# Altium schematic sheet 最小系统.SchDoc
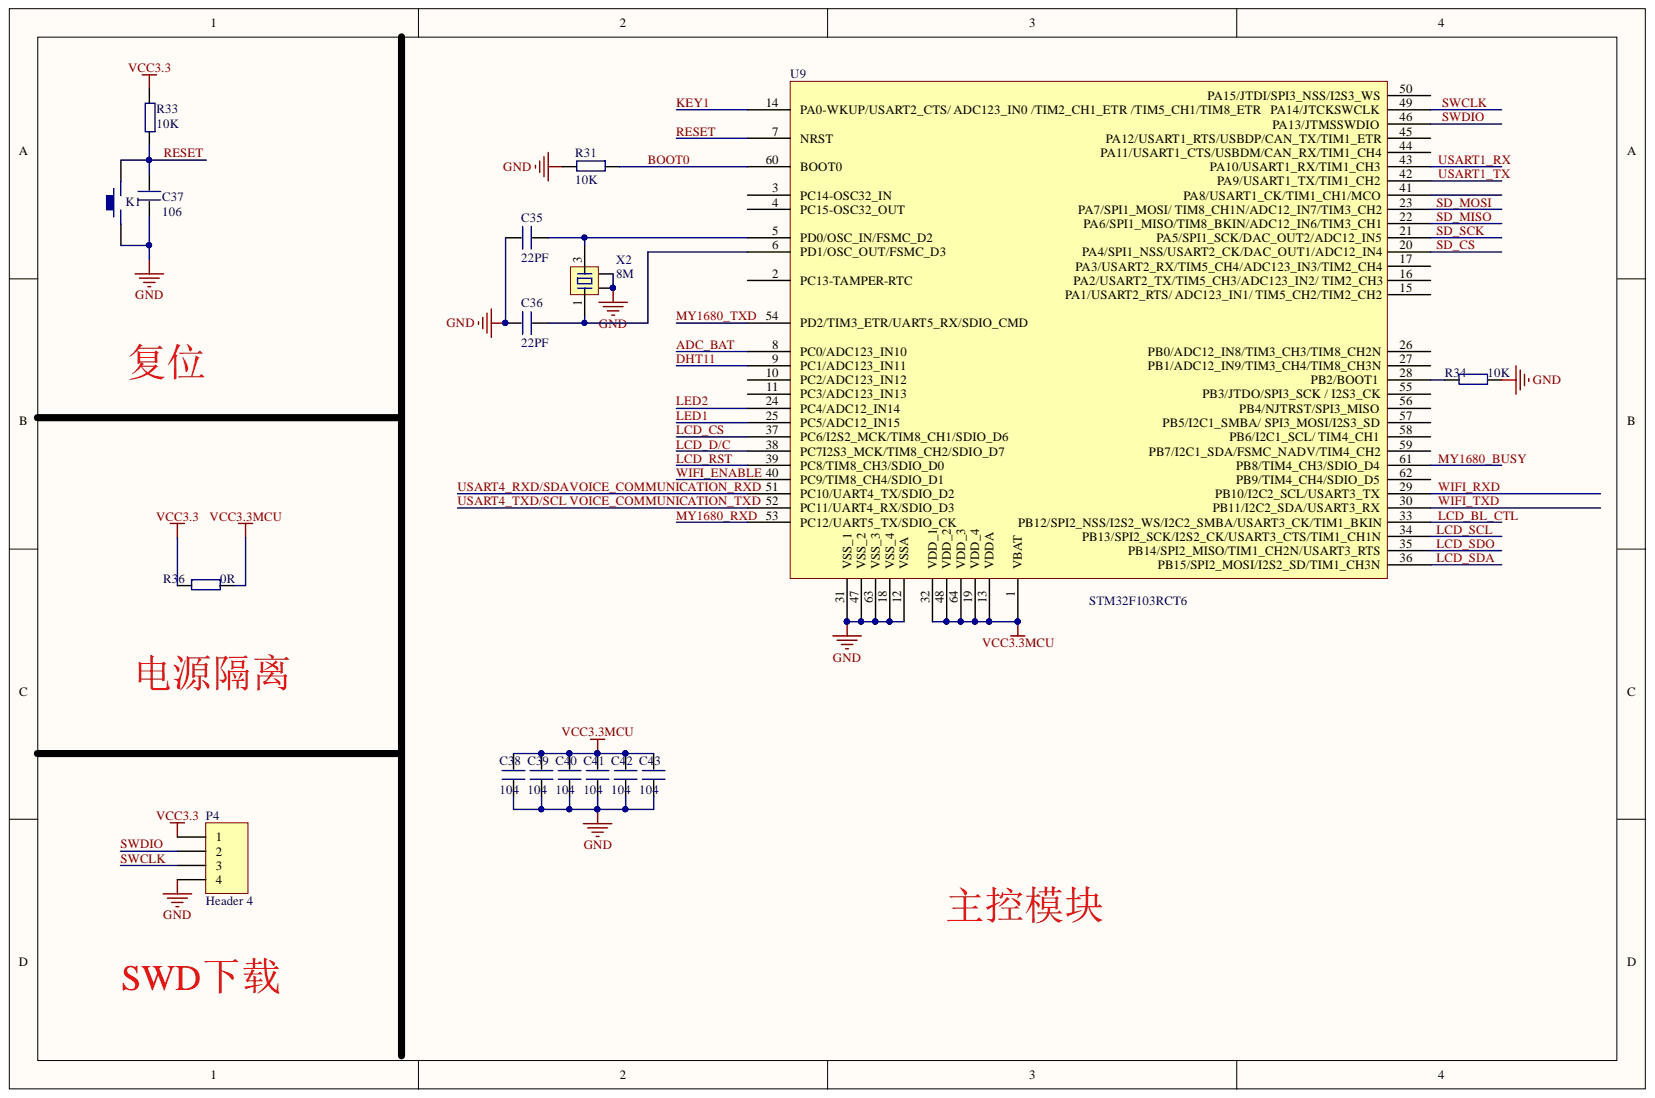
[diagram p0: 最小系统.SchDoc]

## Parts
C35  value 22PF  [0603C]  (hidden comment: CAP)
C36  value 22PF  [0603C]  (hidden comment: CAP)
C37  value 106  [0603C]  (hidden comment: CAP)
C38  value 104  [0603C]  (hidden comment: CAP)
C39  value 104  [0603C]  (hidden comment: CAP)
C40  value 104  [0603C]  (hidden comment: CAP)
C41  value 104  [0603C]  (hidden comment: CAP)
C42  value 104  [0603C]  (hidden comment: CAP)
C43  value 104  [0603C]  (hidden comment: CAP)
K1  KEY_M  [KEY_SMD]  (value not drawn)
P4  Header 4  [HDR1X4]
R31  value 10K  [0603R]  (hidden comment: RES)
R33  RES  value 10K  [0603R]
R34  value 10K  [0603R]  (hidden comment: RES)
R36  value 0R  [0603R]  (hidden comment: RES)
U9  STM32F103RCT6  [LQFP-64]
X2  8M  [3225]

## Nets
GND: C35.1 C36.1 C37.2 C38.2 C39.2 C40.2 C41.2 C42.2 C43.2 K1.1 P4.4 R31.2 R34.1 U9.12(VSSA) U9.18(VSS_4) U9.31(VSS_1) U9.47(VSS_2) U9.63(VSS_3) X2.2 X2.4
VCC3.3: P4.1 R33.2 R36.2
VCC3.3MCU: C38.1 C39.1 C40.1 C41.1 C42.1 C43.1 R36.1 U9.1(VBAT) U9.13(VDDA) U9.19(VDD_4) U9.32(VDD_1) U9.48(VDD_2) U9.64(VDD_3)
ADC_BAT: U9.8(PC0/ADC123_IN10)
BOOT0: R31.1 U9.60(BOOT0)
DHT11: U9.9(PC1/ADC123_IN11)
KEY1: U9.14
LCD_BL_CTL: U9.33(PB12/SPI2_NSS/I2S2_WS/I2C2_SMBA/USART3_CK/TIM1_BKIN)
LCD_CS: U9.37(PC6/I2S2_MCK/TIM8_CH1/SDIO_D6)
LCD_D/C: U9.38(PC7I2S3_MCK/TIM8_CH2/SDIO_D7)
LCD_RST: U9.39(PC8/TIM8_CH3/SDIO_D0)
LCD_SCL: U9.34(PB13/SPI2_SCK/I2S2_CK/USART3_CTS/TIM1_CH1N)
LCD_SDA: U9.36(PB15/SPI2_MOSI/I2S2_SD/TIM1_CH3N)
LCD_SDO: U9.35(PB14/SPI2_MISO/TIM1_CH2N/USART3_RTS)
LED1: U9.25(PC5/ADC12_IN15)
LED2: U9.24(PC4/ADC12_IN14)
MY1680_BUSY: U9.61(PB8/TIM4_CH3/SDIO_D4)
MY1680_RXD: U9.53(PC12/UART5_TX/SDIO_CK)
MY1680_TXD: U9.54(PD2/TIM3_ETR/UART5_RX/SDIO_CMD)
RESET: C37.1 K1.2 R33.1 U9.7(NRST)
SD_CS: U9.20(PA4/SPI1_NSS/USART2_CK/DAC_OUT1/ADC12_IN4)
SD_MISO: U9.22(PA6/SPI1_MISO/TIM8_BKIN/ADC12_IN6/TIM3_CH1)
SD_MOSI: U9.23(PA7/SPI1_MOSI/_TIM8_CH1N/ADC12_IN7/TIM3_CH2)
SD_SCK: U9.21(PA5/SPI1_SCK/DAC_OUT2/ADC12_IN5)
SWCLK: P4.3 U9.49(PA14/JTCKSWCLK)
SWDIO: P4.2 U9.46(PA13/JTMSSWDIO)
USART1_RX: U9.43(PA10/USART1_RX/TIM1_CH3)
USART1_TX: U9.42(PA9/USART1_TX/TIM1_CH2)
USART4_RXD/SDA: U9.51(PC10/UART4_TX/SDIO_D2)
USART4_TXD/SCL: U9.52(PC11/UART4_RX/SDIO_D3)
WIFI_ENABLE: U9.40(PC9/TIM8_CH4/SDIO_D1)
WIFI_RXD: U9.29(PB10/I2C2_SCL/USART3_TX)
WIFI_TXD: U9.30(PB11/I2C2_SDA/USART3_RX)
NetC35_2: C35.2 U9.5(PD0/OSC_IN/FSMC_D2) X2.3
NetC36_2: C36.2 U9.6(PD1/OSC_OUT/FSMC_D3) X2.1
NetR34_2: R34.2 U9.28(PB2/BOOT1)

Not connected: U9 2(PC13-TAMPER-RTC) 3(PC14-OSC32_IN) 4(PC15-OSC32_OUT) 10(PC2/ADC123_IN12) 11(PC3/ADC123_IN13) 15(PA1/USART2_RTS/_ADC123_IN1/_TIM5_CH2/TIM2_CH2) 16(PA2/USART2_TX/TIM5_CH3/ADC123_IN2/_TIM2_CH3) 17(PA3/USART2_RX/TIM5_CH4/ADC123_IN3/TIM2_CH4) 26(PB0/ADC12_IN8/TIM3_CH3/TIM8_CH2N) 27(PB1/ADC12_IN9/TIM3_CH4/TIM8_CH3N) 41(PA8/USART1_CK/TIM1_CH1/MCO) 44(PA11/USART1_CTS/USBDM/CAN_RX/TIM1_CH4) 45(PA12/USART1_RTS/USBDP/CAN_TX/TIM1_ETR) 50(PA15/JTDI/SPI3_NSS/I2S3_WS) 55(PB3/JTDO/SPI3_SCK_/_I2S3_CK) 56(PB4/NJTRST/SPI3_MISO) 57(PB5/I2C1_SMBA/_SPI3_MOSI/I2S3_SD) 58(PB6/I2C1_SCL/_TIM4_CH1) 59(PB7/I2C1_SDA/FSMC_NADV/TIM4_CH2) 62(PB9/TIM4_CH4/SDIO_D5)

## Library data of the parts
P4 = Header 4: Header, 4-Pin; Component Kind: Standard; LatestRevisionDate: 17-Jul-2002; LatestRevisionNote: Re-released for DXP Platform.; Library Name: Miscellaneous Connectors.IntLib; Signal Integrity: Connector; Publisher: Altium Limited
X2 = XTAL-4P: 4脚无源晶振; 版权归属: 源创客; BOM名称: 4脚无源晶振; Component Kind: Standard; Library Name: 10.1 - 保险丝-晶振.SchLib; 学习资源: www.ooocke.com
C35-C43 = CAP: Component Kind: Standard; Description: CAP; Library Name: 主控端最小系统.SCHLIB
K1 = KEY_M: Component Kind: Standard; Library Name: 主控端最小系统.SCHLIB
R31 R33 R34 R36 = RES: Component Kind: Standard; Description: RES; Library Name: 主控端最小系统.SCHLIB
U9 = STM32F103RCT6: Component Kind: Standard; Library Name: 主控端最小系统.SCHLIB
